# Altium schematic sheet Communication.SchDoc
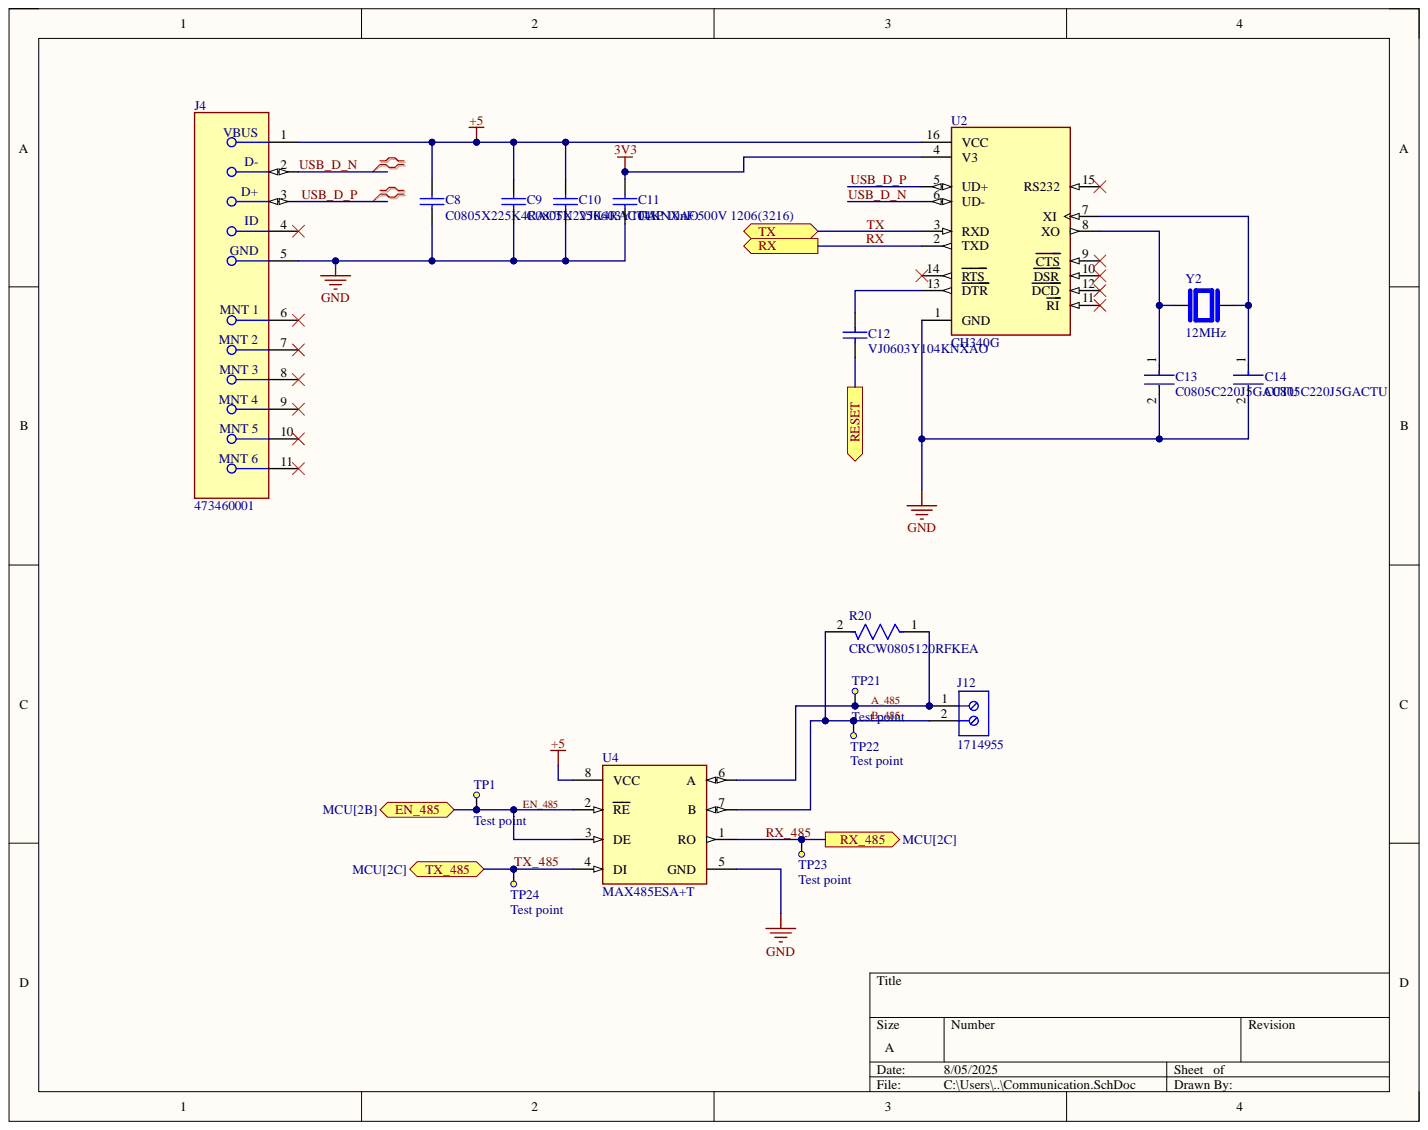
[diagram p0: Communication.SchDoc]

## Parts
C8  C0805X225K4RACTU  [CAPC2013X140X50ML20T25]
C9  C0805X225K4RACTU  [CAPC2013X140X50ML20T25]
C10  VJ0603Y104KNXAO  [CAPC1608X92X38LL15T08]
C11  CAP 10nF 500V 1206(3216)  value 10nF  [CAPC1206(3216)150_M]
C12  VJ0603Y104KNXAO  [CAPC1608X92X38LL15T08]
C13  C0805C220J5GACTU  [FP-C0805C-DN-MFG]
C14  C0805C220J5GACTU  [FP-C0805C-DN-MFG]
J4  473460001  [FP-473460001-MFG]
J12  1714955  [PHOE-1714955_V]
R20  CRCW0805120RFKEA  [FP-CRCW0805-e3-IPC_C]
TP1  Test point  [Test_point]
TP21  Test point  [Test_point]
TP22  Test point  [Test_point]
TP23  Test point  [Test_point]
TP24  Test point  [Test_point]
U2  CH340G  [SOIC127P600X180-16N]
U4  MAX485ESA+T  [FP-S8-2-MFG]
Y2  12MHz  [XTAL_ABLS2-12.000MHZ-D4Y-T]

## Ports
EN_485, RESET, RX, RX_485, TX, TX_485

## Nets
3V3: C11.2 U2.4(V3)
+5: C8.1 C9.1 C10.2 J4.1 U2.16(VCC) U4.8(VCC)
GND: C8.2 C9.2 C10.1 C11.1 C13.2 C14.2 J4.5 U2.1(GND) U4.5(GND)
A_485: J12.1 R20.1 TP21.TP? U4.6(A)
B_485: J12.2 R20.2 TP22.TP? U4.7(B)
EN_485: TP1.TP? U4.2(RE) U4.3(DE) ; port EN_485
RESET: C12.1 ; port RESET
RX: U2.2(TXD) ; port RX
RX_485: TP23.TP? U4.1(RO) ; port RX_485
TX: U2.3(RXD) ; port TX
TX_485: TP24.TP? U4.4(DI) ; port TX_485
USB_D_N: J4.2 U2.6(UD-)
USB_D_P: J4.3 U2.5(UD+)
NetC12_2: C12.2 U2.13(DTR)
NetC13_1: C13.1 U2.8(XO) Y2.1
NetC14_1: C14.1 U2.7(XI) Y2.2

Not connected: J4 4 6 7 8 9 10 11; U2 9(CTS) 10(DSR) 11(RI) 12(DCD) 14(RTS) 15(RS232)

## Library data of the parts
C8 C9 = CMP-2007-03313-1: Manufacturer: Kemet; Component Kind: Standard; Library Name: Altium Content Vault; Part Number: C0805X225K4RACTU
C10 C12 = CMP-1035-02588-2: Manufacturer: Vitramon; Component Kind: Standard; Library Name: Altium Content Vault; Part Number: VJ0603Y104KNXAO
C11 = CMP-1037-03540-2: CAP 10nF 500V ±10% 1206 (3216 Metric) Thickness 1.5mm SMD; Case-EIA: 1206; Case-Metric: 3216; Tolerance: Â±10%; Component Kind: Standard; Library Name: Altium Content Vault; Max Thickness: 1.5 mm; Rated Voltage: 500 V; Technology: SMT
C13 C14 = CMP-2007-00048-2: Capacitor; Ceramic; Cap; 22.0pF; Tol 5%; SMT; Vol-Rtg 50V; C0G; Tape and Reel; Manufacturer: KEMET; Capacitance: 22 pF; Temperature Coefficient: 30ppm/°C; Tolerance: 0.05; Value: 22 pF; Automotive: No; Category: Cap; Component Kind: Standard; ComponentLink2Description: Datasheet; ComponentLink2URL: https://content.kemet.com/datasheets/KEM_C1003_C0G_SMD.pdf; Device Class L1: Passive Components; Device Class L2: Capacitors; Device Class L3: Ceramic Capacitors; Digikey Description: Capacitor; Ceramic; Cap; 22.0pF; Tol 5%; SMT; Vol-Rtg 50V; C0G; Tape and Reel; Height: 0.88mm; Ipc Land Pattern Name: CAPC200125X78; Lead Free: Yes; Library Name: Altium Content Vault; Manufacturer Part Number: C0805C220J5GACTU; Material: Ceramic; Mouser Description: Multilayer Ceramic Capacitors MLCC - SMD/SMT 50volts 22pF C0G 5%; Octopart: https://octopart.com/c0805c220j5gactu-kemet-145470; Package: C0805C-DN; RoHS: TRUE; Temperature: -55°C to +125°C; Temperature Characteristic: C0G; Temperature Range High: +125°C; Temperature Range Low: -55°C; Voltage Rating: 50 V
J4 = CMP-2000-05246-2: CONN RCPT USB2.0 MICRO B SMD R/A; Manufacturer: Molex; Conn Orientation: Right Angle; Current Rating: 1.8A; Is Female: yes; Number Of Contacts: 5; Pitch: 0.65mm; Shielding: true; Voltage Rating: 30V; Automotive: No; Category: Conn; Component Kind: Standard; ComponentLink2Description: Datasheet; ComponentLink2URL: https://www.molex.com/webdocs/datasheets/pdf/en-us/0473460001_IO_CONNECTORS.pdf; Contact Material: Gold,Nickel; Device Class L1: Connectors; Device Class L2: USB Connectors; Device Class L3: unset; Digikey Description: CONN RCPT USB2.0 MICRO B SMD R/A; Footprint Url: https://www.molex.com/pdm_docs/sd/473460001_sd.pdf; Height: 2.945mm; Lead Free: Yes; Library Name: Altium Content Vault; Manufacturer Part Number: 473460001; Octopart: https://octopart.com/47346-0001-molex-7164928; Package: 0; RoHS: TRUE; Temperature: -30°C to +85°C; Temperature Range High: +85°C; Temperature Range Low: -30°C; Usb Standard: USB 2.0
J12 = CMP-2000-05797-1: PC Terminal Block, Pitch 6.35 mm, 1 x 2 Position, Height 21.5 mm, Tail Lenght 5.1 mm, RoHS, Bulk; Manufacturer: Phoenix Contact; Component Kind: Standard; Datasheet URL: http://media.digikey.com/pdf/Data%20Sheets/Phoenix%20Contact%20PDFs/1714955.pdf; Datasheet Version: 02/2010; Library Name: Altium Content Vault; Manufacturer URL: http://www.phoenixcontact.com/; Mounting Technology: ThroughHole; Package Description: PC Terminal Block, Pitch 6.35 mm, 1 x 2 Position, Height 21.5 mm, Tail Lenght 5.1 mm; Package Reference: 1714955
R20 = CMP-02407-007854-1: RES Thick Film, 120Ω, 1%, 0.125W, 100ppm/°C, 0805; Max Power Dissipation: 125mW; Resistance: 120R; Voltage Rating: 150V; Case Code (Imperial): 0805; Case Code (Metric): 2012; Case/Package: 0805; Component Kind: Standard; Composition: Thick Film; Contact Plating: Tin; Depth: 1.25mm; Features: Automotive AEC-Q200; Height: 0.45mm; Lead Free: Lead Free; Length: 2mm; Library Name: Altium Content Vault; Max Operating Temperature: 155°C; Military Standard: Not; Min Operating Temperature: -55°C; Mount: Surface Mount; Number of Terminations: 2; Package Quantity: 10; Packaging: Tape and Reel; Power Rating: 125mW; Radiation Hardening: No; Temperature Coefficient: 100ppm/°C; Termination: SMD/SMT; Tolerance: 1%; Voltage Rating (DC): 150V; Weight: 0.000194oz; Width: 1.25mm
TP1 TP21-TP24 = test_point: Component Kind: Standard; Library Name: SL1.SchLib; Value: Test point
U2 = CH340G: USB Interface Integrated Circuit; MF: WCH; Availability: Not in stock; Check_prices: https://www.snapeda.com/parts/Ch340G/WCH/view-part/?ref=eda; Component Kind: Standard; Library Name: CH340G.IntLib; MP: Ch340G; Package: None; Price: None; SnapEDA_Link: https://www.snapeda.com/parts/Ch340G/WCH/view-part/?ref=snap
U4 = CMP-05679-000009-1: IC TRANSCEIVER HALF 1/1 8SOIC; Manufacturer: Maxim Integrated; Data Rate: 2.5Mbits/s; Interface: RS-485,RS-422; Max Supply Voltage: 5.25V; Min Supply Voltage: 4.75V; Nominal Supply Current: 0.5mA; Number Of Receivers: 1; Simplex Duplex: Half-Duplex; Standoff Height: 0.1mm; Automotive: No; Category: IC; Component Kind: Standard; ComponentLink2Description: Datasheet; ComponentLink2URL: https://datasheets.maximintegrated.com/en/ds/MAX1487-MAX491.pdf; Device Class L1: Integrated Circuits (ICs); Device Class L2: Interface ICs; Device Class L3: RS-232 / RS-422 / RS-485 Interface ICs; Digikey Description: IC TRANSCEIVER HALF 1/1 8SOIC; Footprint Url: https://pdfserv.maximintegrated.com/land_patterns/90-0096.PDF; Height: 1.75mm; Ipc Land Pattern Name: SOIC127P600X155-8; Lead Free: Yes; Library Name: Altium Content Vault; Manufacturer Part Number: MAX485ESA+T; Mouser Description: RS-422/RS-485 Interface IC RS-485/RS-422 Transceiver; Number Of Transmitters: 1; Octopart: https://octopart.com/max485esa%2Bt-maxim+integrated-40011239; Package: S8-2; RoHS: TRUE; Temperature: -40°C to +85°C; Temperature Range High: +85°C; Temperature Range Low: -40°C
Y2 = ABLS2-12.000MHZ-D4Y-T: HC/49US (AT49) LOW PROFILE SURFACE MOUNT MICROPROCESSOR CRYSTAL; MF: Abracon; Availability: In Stock; Check_prices: https://www.snapeda.com/parts/ABLS2-12.000MHZ-D4Y-T/Abracon+LLC/view-part/?ref=eda; Component Kind: Standard; Library Name: ABLS2-12.000MHZ-D4Y-T.IntLib; MP: ABLS2-12.000MHZ-D4Y-T; Package: HC49/US Abracon; Price: None; Purchase-URL: https://pricing.snapeda.com/search/part/ABLS2-12.000MHZ-D4Y-T/?ref=eda; SnapEDA_Link: https://www.snapeda.com/parts/ABLS2-12.000MHZ-D4Y-T/Abracon+LLC/view-part/?ref=snap
